# Altium schematic sheet SensorsConnectorBrd.SchDoc(SensorsConnectorBrd)
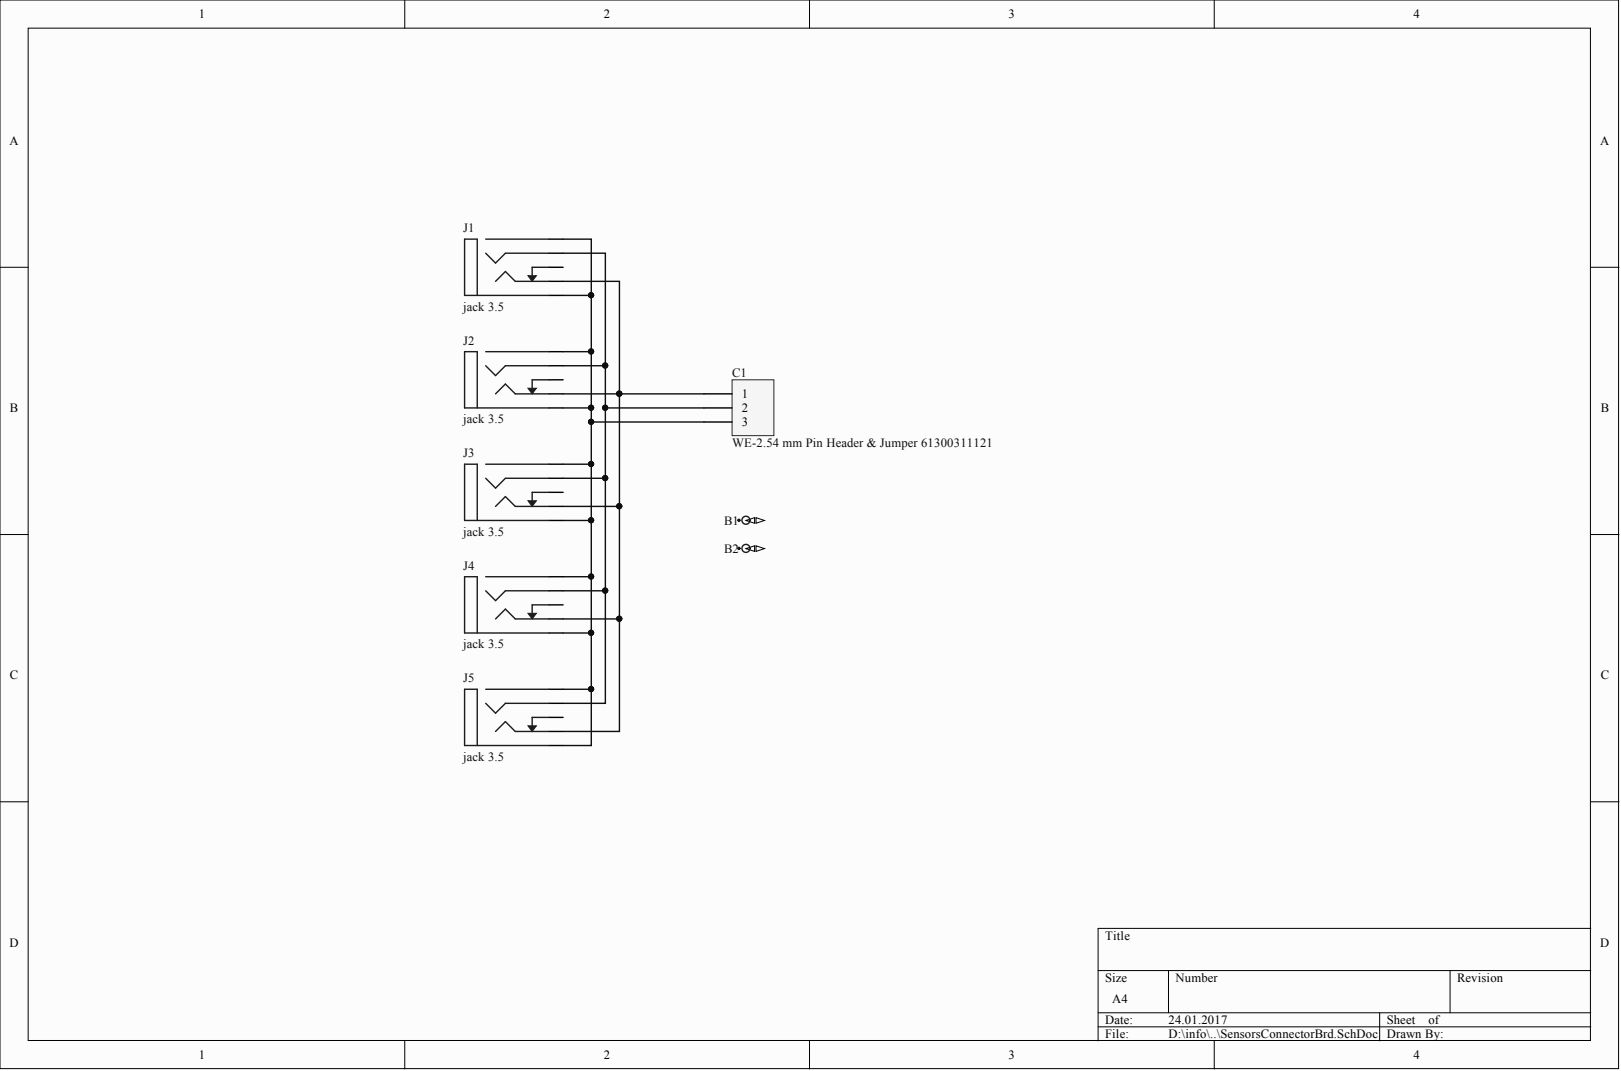
[diagram p0: SensorsConnectorBrd.SchDoc]

## Parts
B1  Non Plated Hole  [MTG300]  (value not drawn)  (no BOM)
B2  Non Plated Hole  [MTG300]  (value not drawn)  (no BOM)
C1  WE-2.54 mm Pin Header & Jumper 61300311121  [61300311121]
J1  jack 3.5  [Jak-Ph St 6x14]
J2  jack 3.5  [Jak-Ph St 6x14]
J3  jack 3.5  [Jak-Ph St 6x14]
J4  jack 3.5  [Jak-Ph St 6x14]
J5  jack 3.5  [Jak-Ph St 6x14]

## Nets
NetC1_1: C1.1 J1.4 J2.4 J3.4 J4.4 J5.4
NetC1_2: C1.2 J1.3 J2.3 J3.3 J4.3 J5.3
NetC1_3: C1.3 J1.1 J1.2 J2.1 J2.2 J3.1 J3.2 J4.1 J4.2 J5.1 J5.2

Not connected: B1 1; B2 1; J1 5; J2 5; J3 5; J4 5; J5 5

## Library data of the parts
B1 B2 = NON-PLATED_HOLE3.0: Single Terminal Socket; Part Description: Non Plated Through Hole: Hole Dia.=3.0mm; Database Table Name: Pads; Mounted: No; ComponentHeight: 0mm; Component Type: Standard (No BOM); CreateDate: 26.05.2008; Family: Non Plated Hole; LatestRevisionDate: 26.05.2008; Library Name: Eletro-mechanical.DbLib; PackageDescription: Non Plated Through Hole: Hole Dia.=3.0mm; Part Number: NON-PLATED_HOLE3.0; Pin Count: 1; SMD: No; Socket: No; Status: None; Table: Pads; Author: CERN DEM JLC
C1 = WE-2.54 mm Pin Header & Jumper 61300311121: WR-PHD 2.54mm Pin Header; Manufacturer: WЁ№rth Elektronik eiSos GmbH; ComponentLink1 Description: Manufacturer; ComponentLink2 Description: Datasheet; NoPins: 03; Component Kind: Standard; ComponentLink1URL: http://www.we-online.com; ComponentLink2URL: http://katalog.we-online.de/em/datasheet/6130xx11121.pdf; Created Date: 22 March 2013; Library Name: WE_WR-PHD_Pin Header_2.54mm_rev15c.IntLib; partNo: 61300311121; Created by: Karrer Zheng
J1-J5 = jack 3.5: Component Kind: Standard; Description: jack 3.5; Library Name: PowerLib.SchLib
